# Altium schematic sheet EXTRA-2.SchDoc(EXTRA-2)
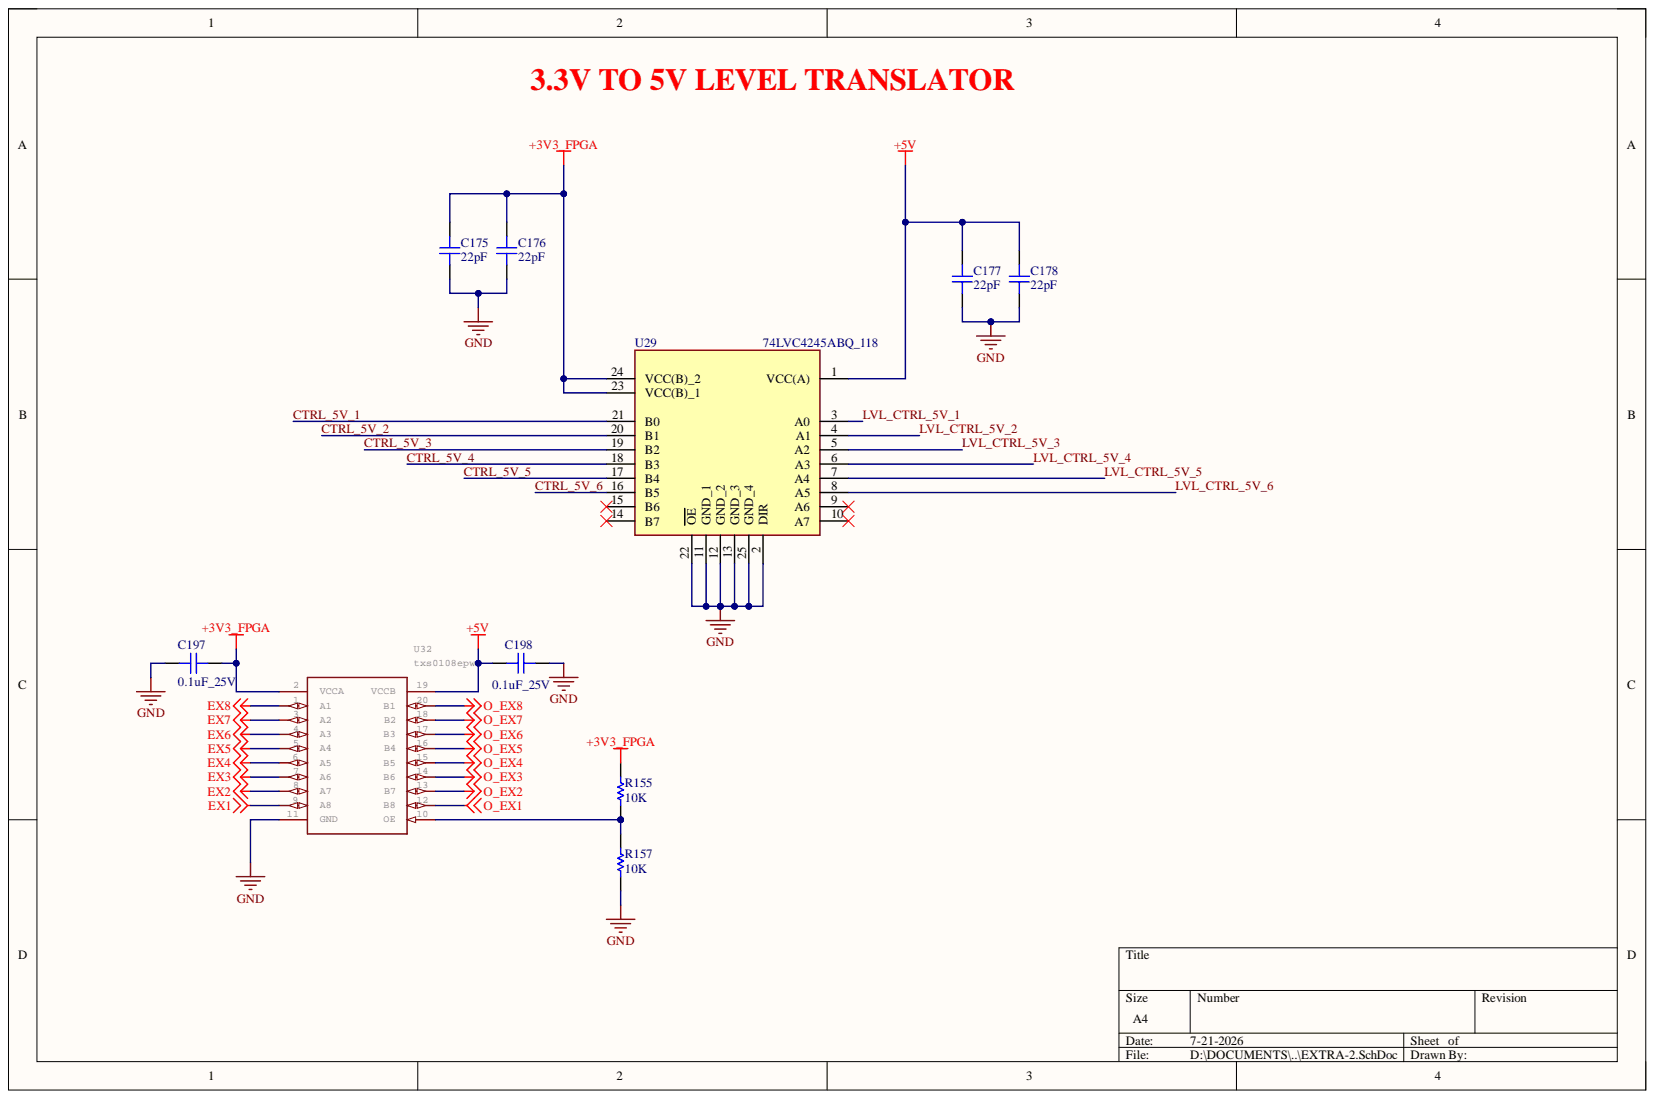
[diagram p0: EXTRA-2.SchDoc]

## Parts
C175  22pF  [CAP_0402]
C176  22pF  [CAP_0402]
C177  22pF  [CAP_0402]
C178  22pF  [CAP_0402]
C197  0.1uF_25V  [CAP_0603]
C198  0.1uF_25V  [CAP_0603]
R155  10K  [RES_0402]
R157  10K  [RES_0402]
U29  74LVC4245ABQ_118  [74LVC4245ABQ118]
U32  txs0108epwr  [SOP65P640X120-20N]

## Nets
+3V3_FPGA: C175.1 C176.1 C197.1 R155.2 U29.23(VCC(B)_1) U29.24(VCC(B)_2) U32.2(VCCA)
+5V: C177.1 C178.1 C198.2 U29.1(VCC(A)) U32.19(VCCB)
EX1: U32.9(A8)
EX2: U32.8(A7)
EX3: U32.7(A6)
EX4: U32.6(A5)
EX5: U32.5(A4)
EX6: U32.4(A3)
EX7: U32.3(A2)
EX8: U32.1(A1)
GND: C175.2 C176.2 C177.2 C178.2 C197.2 C198.1 R157.1 U29.2(DIR) U29.11(GND_1) U29.12(GND_2) U29.13(GND_3) U29.22(OE) U29.25(GND_4) U32.11(GND)
O_EX1: U32.12(B8)
O_EX2: U32.13(B7)
O_EX3: U32.14(B6)
O_EX4: U32.15(B5)
O_EX5: U32.16(B4)
O_EX6: U32.17(B3)
O_EX7: U32.18(B2)
O_EX8: U32.20(B1)
CTRL_5V_1: U29.21(B0)
CTRL_5V_2: U29.20(B1)
CTRL_5V_3: U29.19(B2)
CTRL_5V_4: U29.18(B3)
CTRL_5V_5: U29.17(B4)
CTRL_5V_6: U29.16(B5)
LVL_CTRL_5V_1: U29.3(A0)
LVL_CTRL_5V_2: U29.4(A1)
LVL_CTRL_5V_3: U29.5(A2)
LVL_CTRL_5V_4: U29.6(A3)
LVL_CTRL_5V_5: U29.7(A4)
LVL_CTRL_5V_6: U29.8(A5)
NetR155_1: R155.1 R157.2 U32.10(OE)

Not connected: U29 9(A6) 10(A7) 14(B7) 15(B6)

## Library data of the parts
C175-C178 = CAP_0402_50V: 04023A220FAT2A; Component Kind: Standard; Library Name: Schematic-Lib-PhilsLab.SchLib
C197 C198 = CAP_0603_16V: C0603_16V; Component Kind: Standard; Library Name: MAX10.SchLib
R155 R157 = RES_0402: R0402; Component Kind: Standard; Library Name: MAX10.SchLib
U29 = 74LVC4245ABQ_118: 74LVC4245A - Octal dual supply translating transceiver; 3-state@en-us; Manufacturer_Name: Nexperia; Manufacturer_Part_Number: 74LVC4245ABQ,118; Geometry.Height: 1mm; Component Kind: Standard; Datasheet Link: https://assets.nexperia.com/documents/data-sheet/74LVC4245A.pdf; Mouser Part Number: 771-74LVC4245ABQ,118; Mouser Price/Stock: https://www.mouser.co.uk/ProductDetail/Nexperia/74LVC4245ABQ118?qs=6YAT8rHPFU%252BdBSdzEJ9uxw%3D%3D; Library Name: ANAND_LIB.SchLib
U32 = TXS0108EQPWRQ1: Manufacturer_Name: Texas Instruments; GateName_1: G$1; Component Kind: Standard; DeviceSetName: TXS0108EQPWRQ1; Height: 1.2mm; Library Name: MAX10.SchLib; LibraryName: TXS0108EQPWRQ1; Manufacturer_Part_Number: TXS0108EQPWRQ1; Mouser_Part_Number: 595-TXS0108EQPWRQ1; Mouser_Price-Stock: https://www.mouser.co.uk/ProductDetail/Texas-Instruments/TXS0108EQPWRQ1?qs=4BLsKd%2FIMYCjsiJW21Sqxg%3D%3D; Package: SOP65P640X120-20N; SymbolName_1: TXS0108EQPWRQ1
